# Altium schematic sheet Sheet1.SchDoc
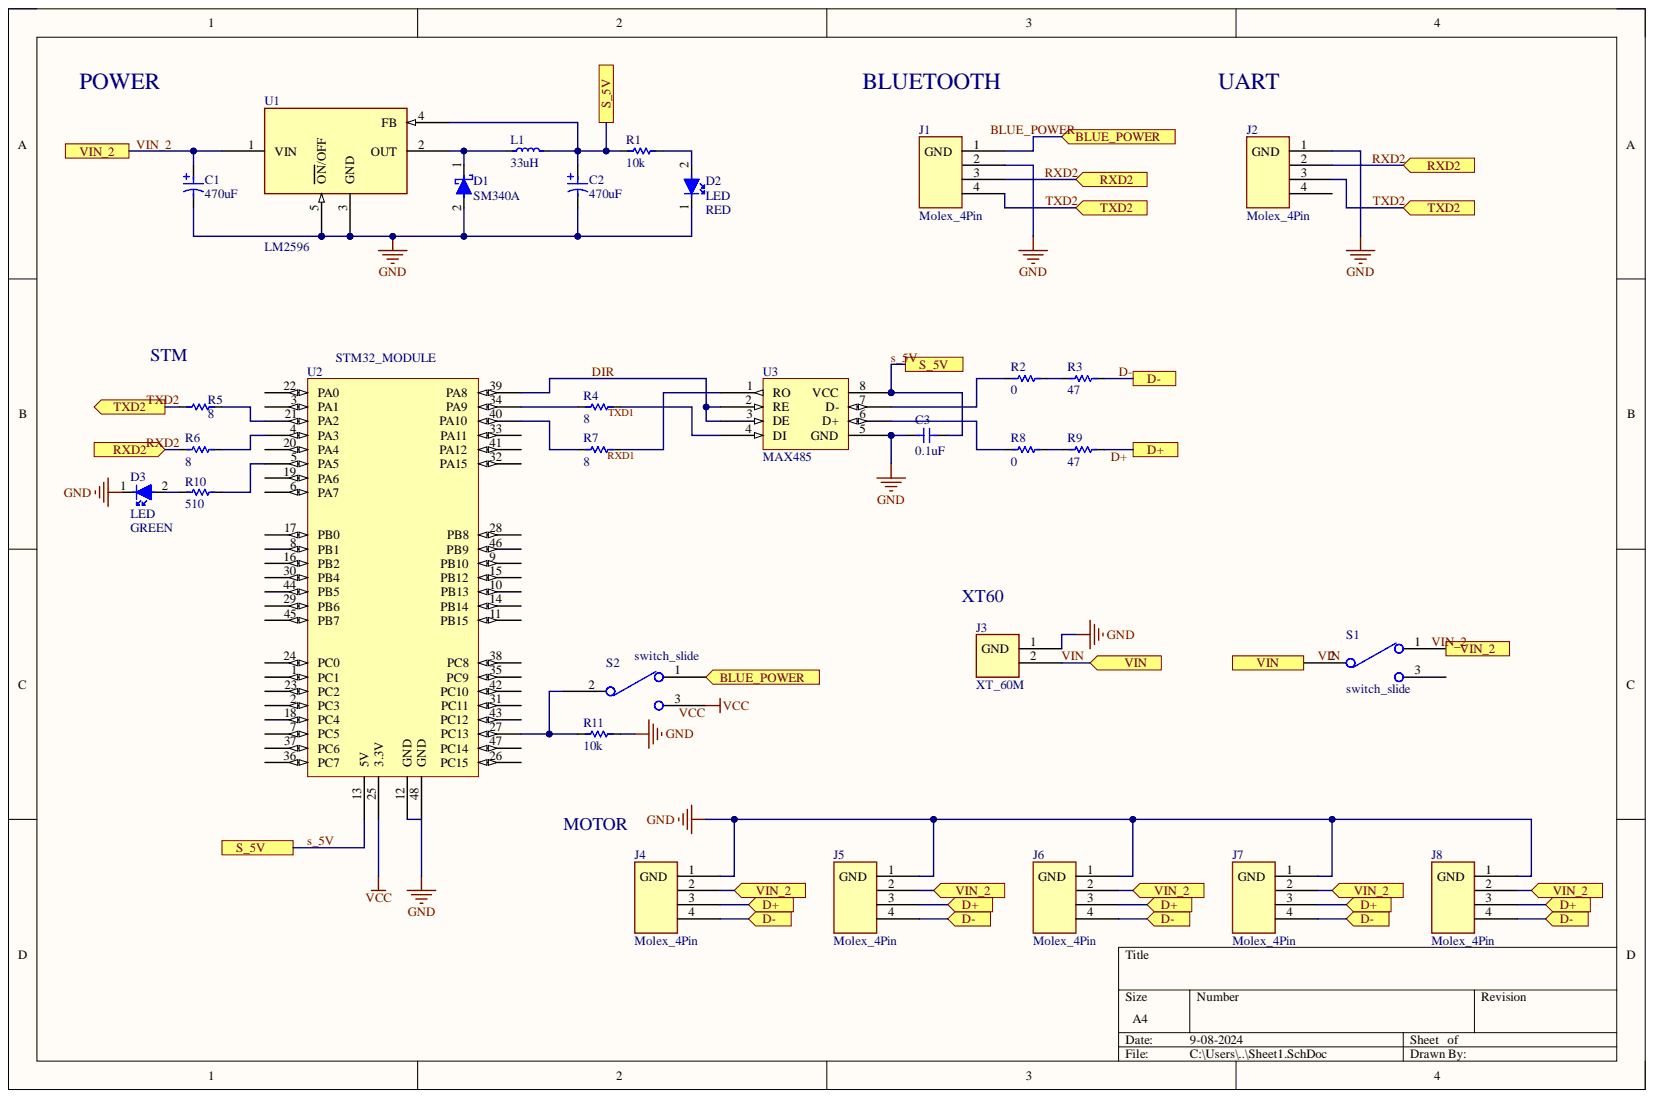
[diagram p0: Sheet1.SchDoc]

## Parts
C1  Capacitance: 470uF  [SMD_Capacitor_electrolytic]  (hidden comment: Polar_Capacitors)
C2  Capacitance: 470uF  [SMD_Capacitor_electrolytic]  (hidden comment: Polar_Capacitors)
C3  Capacitance: 0.1uF  [SMD_Capacitor]  (hidden comment: non-polar_Capacitor)
D1  SM340A  [SMD_Diode_schottky]
D2  LED  Color: RED  [SMD_LED]
D3  LED  Color: GREEN  [SMD_LED]
J1  Molex_4Pin  [MOLEX_4PIN]
J2  Molex_4Pin  [MOLEX_4PIN]
J3  XT_60M  [XT60_M]
J4  Molex_4Pin  [MOLEX_4PIN]
J5  Molex_4Pin  [MOLEX_4PIN]
J6  Molex_4Pin  [MOLEX_4PIN]
J7  Molex_4Pin  [MOLEX_4PIN]
J8  Molex_4Pin  [MOLEX_4PIN]
L1  Inductance: 33uH  [SMD_INDUCTOR_POWER]  (hidden comment: Inductor)
R1  Resistance: 10k  [SMD_Resistor]  (hidden comment: Resistor)
R2  Resistor  [SMD_Resistor]  (value not drawn)
R3  Resistor  [SMD_Resistor]  (value not drawn)
R4  Resistor  [SMD_Resistor]  (value not drawn)
R5  Resistor  [SMD_Resistor]  (value not drawn)
R6  Resistor  [SMD_Resistor]  (value not drawn)
R7  Resistor  [SMD_Resistor]  (value not drawn)
R8  Resistor  [SMD_Resistor]  (value not drawn)
R9  Resistor  [SMD_Resistor]  (value not drawn)
R10  Resistor  [SMD_Resistor]  (value not drawn)
R11  Resistance: 10k  [SMD_Resistor]  (hidden comment: Resistor)
S1  switch_slide  [DIP_SW_SLIDE]
S2  switch_slide  [DIP_SW_SLIDE]
U1  LM2596  [SMD_LM2596]
U2  STM32_MODULE  [DIP_STM32_MODULE]
U3  MAX485  [SMD_MAX485]

## Ports
BLUE_POWER, D+, D-, RXD2, S_5V, TXD2, VIN, VIN_2

## Nets
GND: C1.1 C2.1 C3.1 D1.2 D2.1 D3.1 J1.2 J2.1(GND) J3.1 J4.1(GND) J5.1(GND) J6.1(GND) J7.1(GND) J8.1(GND) R11.2 U1.3(GND) U1.5(ON/OFF) U2.48(GND) U3.5(GND)
BLUE_POWER: J1.1(GND) S2.1 ; port BLUE_POWER
D+: J4.3 J5.3 J6.3 J7.3 J8.3 R9.2 ; port D+
D-: J4.4 J5.4 J6.4 J7.4 J8.4 R3.2 ; port D-
DIR: U2.39(PA8) U3.2(RE) U3.3(DE)
RXD1: R7.2 U3.1(RO)
RXD2: J1.3 J2.2 R6.1 ; port RXD2
s_5V: C2.2 C3.2 L1.2 R1.1 U1.4(FB) U2.13(5V) U3.8(VCC) ; port S_5V
TXD1: R4.2 U3.4(DI)
TXD2: J1.4 J2.3 R5.1 ; port TXD2
VCC: S2.3 U2.25(3.3V)
VIN: J3.2 S1.2 ; port VIN
VIN_2: C1.2 J4.2 J5.2 J6.2 J7.2 J8.2 S1.1 U1.1(VIN) ; port VIN_2
NetD1_1: D1.1 L1.1 U1.2(OUT)
NetD2_2: D2.2 R1.2
NetD3_2: D3.2 R10.1
NetR2_1: R2.1 U3.7(D-)
NetR2_2: R2.2 R3.1
NetR4_1: R4.1 U2.34(PA9)
NetR5_2: R5.2 U2.21(PA2)
NetR6_2: R6.2 U2.4(PA3)
NetR7_1: R7.1 U2.40(PA10)
NetR8_1: R8.1 U3.6(D+)
NetR8_2: R8.2 R9.1
NetR10_2: R10.2 U2.5(PA5)
NetR11_1: R11.1 S2.2 U2.27(PC13)

Not connected: J2 4; S1 3; U2 1(PC1) 2(PC3) 3(PA1) 6(PA7) 7(PC5) 8(PB1) 9(PB10) 10(PB13) 11(PB15) 12(GND) 14(PB14) 15(PB12) 16(PB2) 17(PB0) 18(PC4) 19(PA6) 20(PA4) 22(PA0) 23(PC2) 24(PC0) 26(PC15) 28(PB8) 29(PB6) 30(PB4) 31(PC11) 32(PA15) 33(PA11) 35(PC9) 36(PC7) 37(PC6) 38(PC8) 41(PA12) 42(PC10) 43(PC12) 44(PB5) 45(PB7) 46(PB9) 47(PC14)

## Library data of the parts
C1 C2 = CMP-024-000022-3: Electrolytic Capacitor; Component Kind: Standard; Design Item ID: Capacitor_electrolytic; Library Name: robit circuit; Source: robit_passive.SchLib
C3 = CMP-024-000020-2: SMD type ceramic capacitor; Component Kind: Standard; Design Item ID: Capacitor; Library Name: robit circuit; Source: robit_passive.SchLib
D1 = CMP-026-000009-1: Schottky Barrier Diode; Component Kind: Standard; Design Item ID: Diode_schottky; Library Name: robit circuit; Source: robit_passive.SchLib
D2 D3 = CMP-026-000008-1: Light Emitting Diode; Component Kind: Standard; Design Item ID: LED; Library Name: robit circuit; Source: robit_passive.SchLib
J1 J2 J4-J8 = CMP-019-000045-1: Molex 4Pin Connector; Component Kind: Standard; Design Item ID: Molex_4Pin; Library Name: robit circuit; Source: robit_connector.SchLib
J3 = CMP-019-000042-1: XT_60 Connector MALE; Component Kind: Standard; Design Item ID: XT_60M; Library Name: robit circuit; Source: robit_connector.SchLib
L1 = CMP-028-000009-2: SMD type Inductor; Component Kind: Standard; Design Item ID: Inductor; Library Name: robit circuit; Source: robit_passive.SchLib
R1 R11 = CMP-029-000004-2: SMD type resistor; Component Kind: Standard; Design Item ID: Resistor; Library Name: robit circuit; Source: robit_passive.SchLib
R2 R8 = CMP-029-000004-2: SMD type resistor; Resistance: 0; Component Kind: Standard; Design Item ID: Resistor; Library Name: robit circuit; Source: robit_passive.SchLib
R3 R9 = CMP-029-000004-2: SMD type resistor; Resistance: 47; Component Kind: Standard; Design Item ID: Resistor; Library Name: robit circuit; Source: robit_passive.SchLib
R4-R7 = CMP-029-000004-2: SMD type resistor; Resistance: 8; Component Kind: Standard; Design Item ID: Resistor; Library Name: robit circuit; Source: robit_passive.SchLib
R10 = CMP-029-000004-2: SMD type resistor; Resistance: 510; Component Kind: Standard; Design Item ID: Resistor; Library Name: robit circuit; Source: robit_passive.SchLib
S1 S2 = CMP-030-000004-1: slide switch; Component Kind: Standard; Design Item ID: switch_slide; Library Name: robit circuit; Source: robit_switch.SchLib
U1 = CMP-022-000007-1: 5V Transformer; Component Kind: Standard; Design Item ID: LM2596; Library Name: robit circuit; Source: robit_ic.SchLib
U2 = CMP-021-000010-1: processor; Component Kind: Standard; Design Item ID: STM32_MODULE; Library Name: robit circuit; Source: robit_ic.SchLib
U3 = CMP-020-000003-1: convertor 232to485; Component Kind: Standard; Design Item ID: MAX485; Library Name: robit circuit; Source: robit_ic.SchLib
